# Altium schematic sheet SDEC945-xB_Interface_BP_et_Prog.SchDoc(SDEC945-xB_Interface_BP_et_Prog)
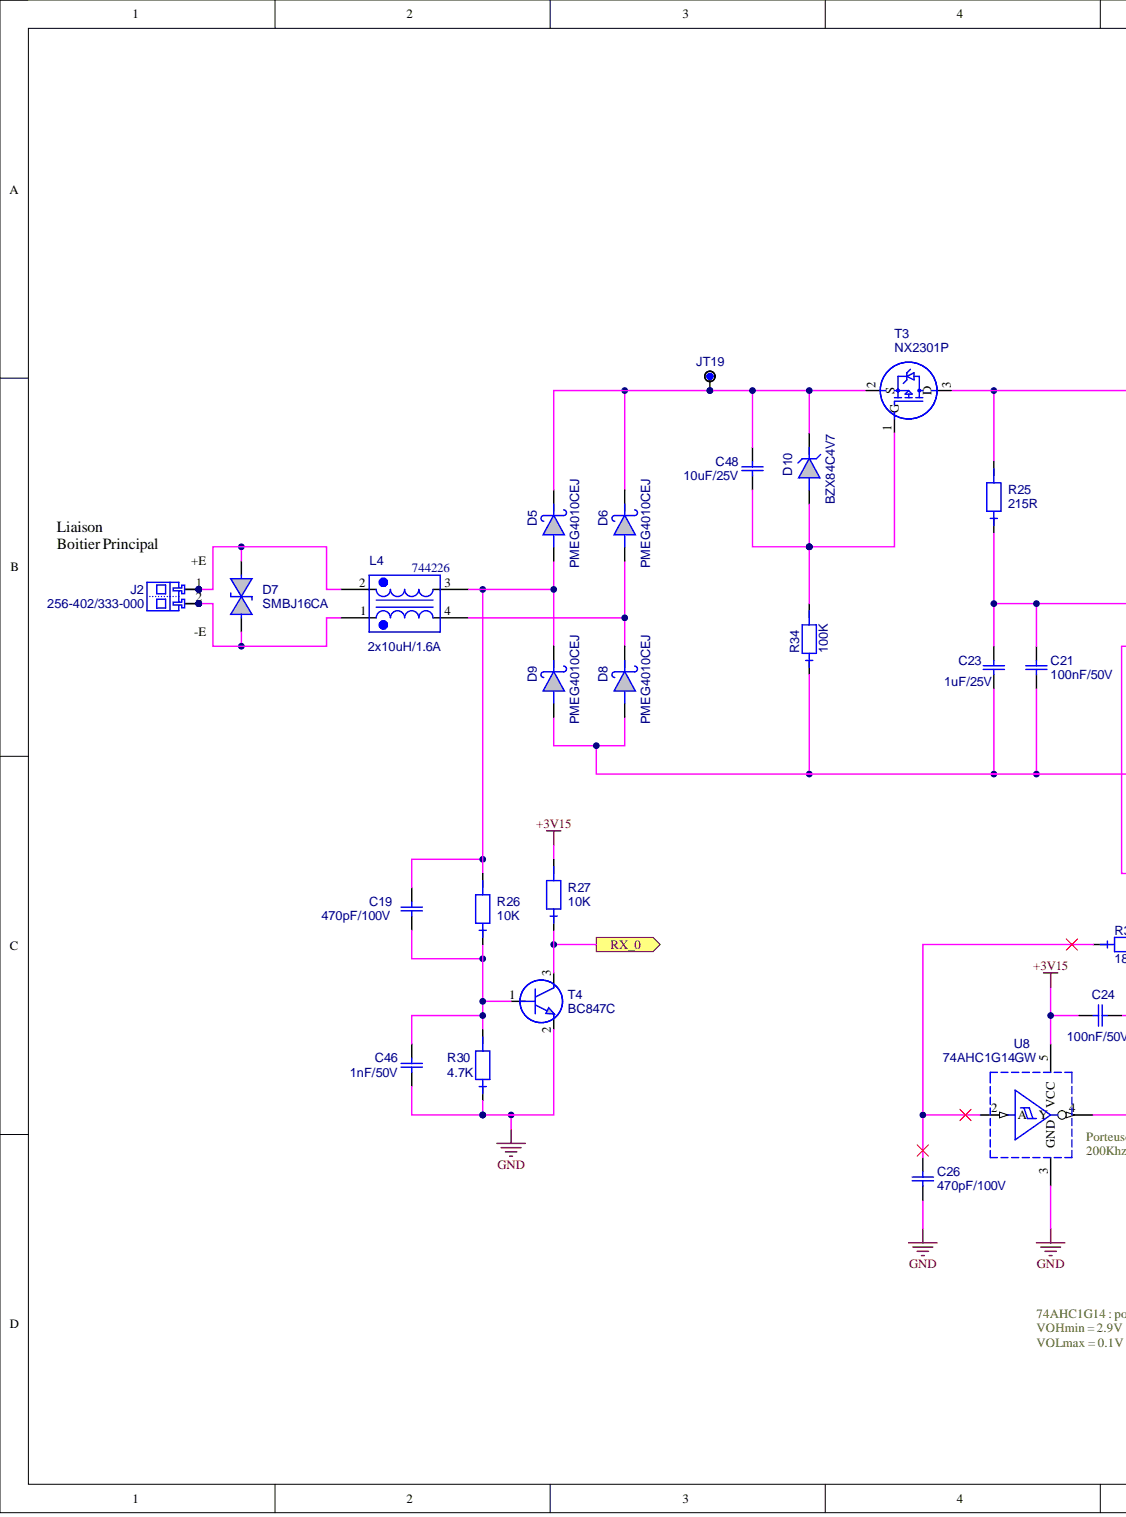
[diagram p0: SDEC945-xB_Interface_BP_et_Prog.SchDoc, left]
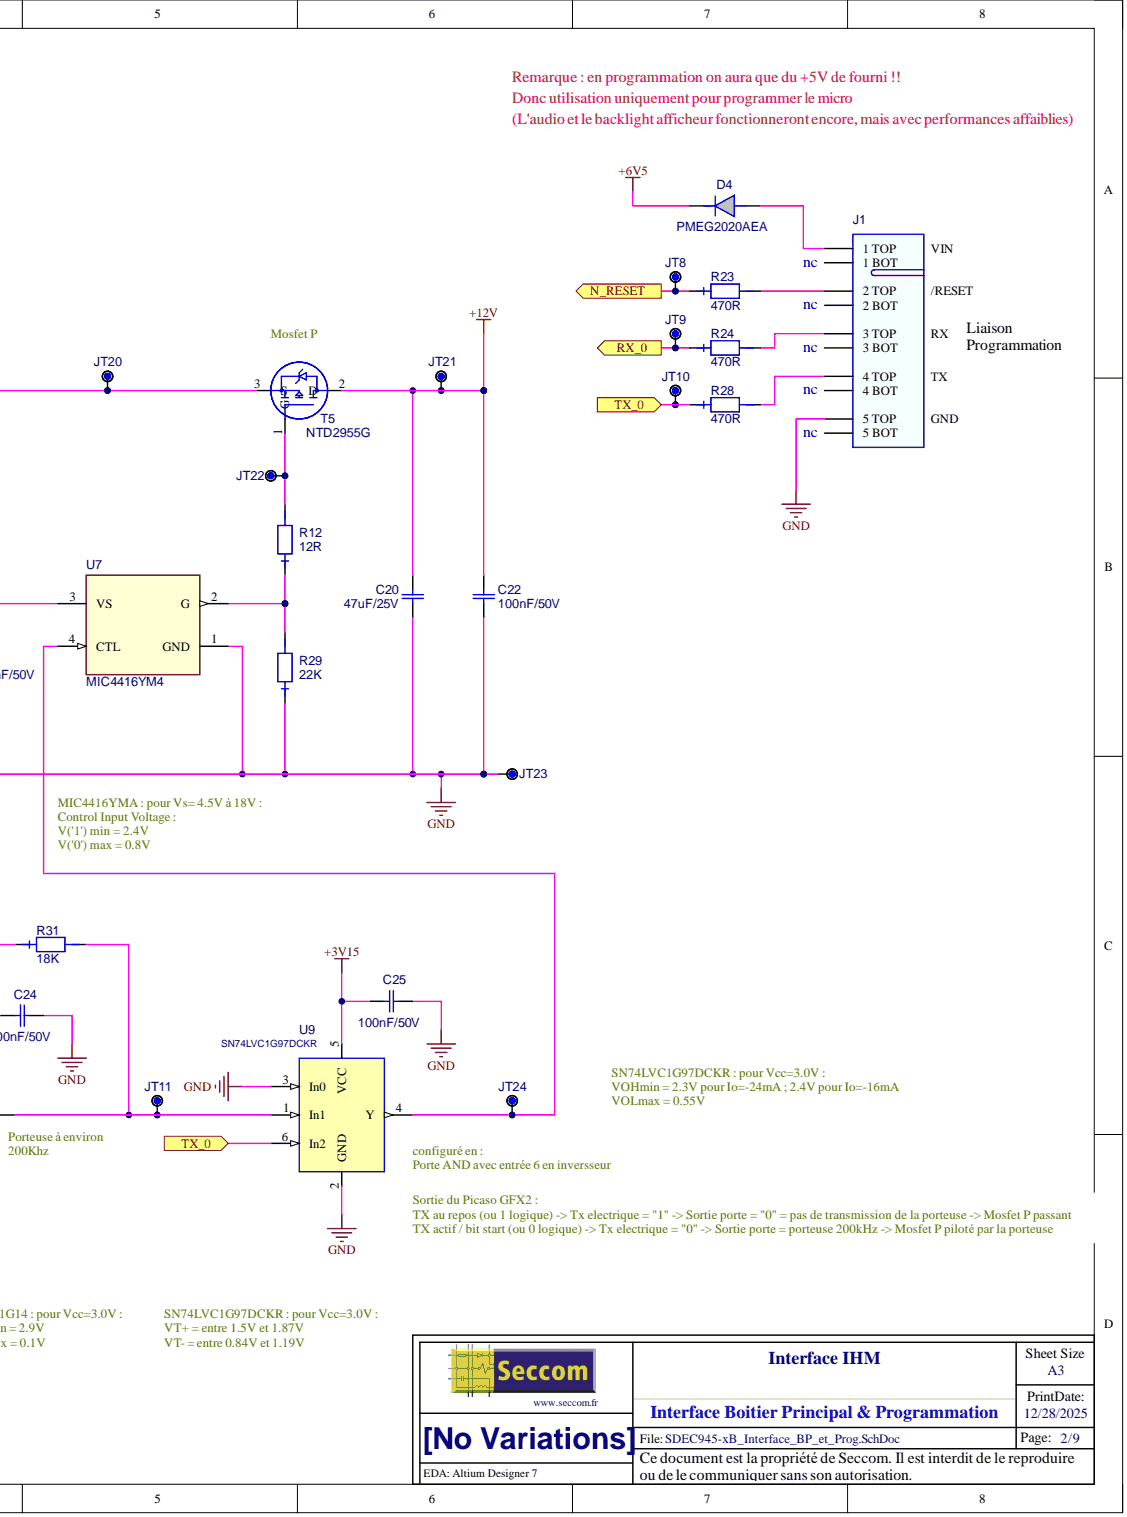
[diagram p1: SDEC945-xB_Interface_BP_et_Prog.SchDoc, right]

## Parts
C19  value 470pF/100V  (hidden comment: C_0805_NPO_5%_470P-100V)
C20  value 47uF/25V  (hidden comment: C_1206_X5R_20%_47U-25V)
C21  value 100nF/50V  (hidden comment: C_0603_X7R_10%_100N-50V)
C22  value 100nF/50V  (hidden comment: C_0603_X7R_10%_100N-50V)
C23  value 1uF/25V  (hidden comment: C_0805_X5R_10%_1U-25V)
C24  value 100nF/50V  (hidden comment: C_0603_X7R_10%_100N-50V)
C25  value 100nF/50V  (hidden comment: C_0603_X7R_10%_100N-50V)
C26  value 470pF/100V  (hidden comment: C_0805_NPO_5%_470P-100V)
C46  value 1nF/50V  (hidden comment: C_0603_NPO_5%_1N-50V)
C48  value 10uF/25V  (hidden comment: C_0805_X5R_10%_10U-25V)
D4  PMEG2020AEA
D5  PMEG4010CEJ
D6  PMEG4010CEJ
D7  SMBJ16CA
D8  PMEG4010CEJ
D9  PMEG4010CEJ
D10  BZX84C4V7
J1  EMB_ZEC_1893711_HOTE  (value not drawn)  (no BOM)
J2  value 256-402/333-000  Ref Fab: 256-402/333-000  (hidden comment: BLEV_CI_45D_5.08_02_256-402/333-000)
JT8  POINT_TEST_PAD_40MIL  (value not drawn)  (no BOM)
JT9  POINT_TEST_PAD_40MIL  (value not drawn)  (no BOM)
JT10  POINT_TEST_PAD_40MIL  (value not drawn)  (no BOM)
JT11  POINT_TEST_PAD_40MIL  (value not drawn)  (no BOM)
JT19  POINT_TEST_PAD_40MIL  (value not drawn)  (no BOM)
JT20  POINT_TEST_PAD_40MIL  (value not drawn)  (no BOM)
JT21  POINT_TEST_PAD_40MIL  (value not drawn)  (no BOM)
JT22  POINT_TEST_PAD_40MIL  (value not drawn)  (no BOM)
JT23  POINT_TEST_PAD_40MIL  (value not drawn)  (no BOM)
JT24  POINT_TEST_PAD_40MIL  (value not drawn)  (no BOM)
L4  value 2x10uH/1.6A  Ref Fab: 744226  (hidden comment: IND_FERRITE_WE-SL2_2X10UH)
R12  value 12R  (hidden comment: RES_0603_1%_100PPM_12R)
R23  value 470R  (hidden comment: RES_0603_1%_100PPM_470R)
R24  value 470R  (hidden comment: RES_0603_1%_100PPM_470R)
R25  value 215R  (hidden comment: RES_0603_1%_100PPM_215R)
R26  value 10K  (hidden comment: RES_0603_1%_100PPM_10K)
R27  value 10K  (hidden comment: RES_0603_1%_100PPM_10K)
R28  value 470R  (hidden comment: RES_0603_1%_100PPM_470R)
R29  value 22K  (hidden comment: RES_0603_1%_100PPM_22K)
R30  value 4.7K  (hidden comment: RES_0603_1%_100PPM_4.7K)
R31  value 18K  (hidden comment: RES_0603_1%_100PPM_18K)
R34  value 100K  (hidden comment: RES_0603_1%_100PPM_100K)
T3  value NX2301P  Ref Fab: NX2301P  (hidden comment: NX2301P_VALENTINEA)
T4  BC847C
T5  NTD2955G
U7  MIC4416YM4
U8  74AHC1G14GW
U9  74LVC1G97DCKR  value SN74LVC1G97DCKR  Ref Fab: SN74LVC1G97DCKR

## Ports
N_RESET, RX_0, TX_0

## Nets
+3V15: C24.2 C25.2 R27.2 U8.5(VCC) U9.5(VCC)
+6V5: D4.K
+12V: C20.2 C22.2 JT21.1 T5.2
GND: C20.1 C21.1 C22.1 C23.1 C24.1 C25.1 C26.1 C46.1 D8.A D9.A J1.5(5_TOP) JT23.1 R29.1 R30.1 R34.1 T4.2 U7.1(GND) U8.3(GND) U9.2(GND) U9.3(In0)
N_RESET: JT8.1 R23.1 ; port N_RESET
RX_0: JT9.1 R24.1 R27.1 T4.3 ; port RX_0
TX_0: JT10.1 R28.1 U9.6(In2) ; port TX_0
NetC19_1: C19.1 C46.2 R26.1 R30.2 T4.1
NetC19_2: C19.2 D5.A D9.K L4.3 R26.2
NetC21_2: C21.2 C23.2 R25.1 U7.3(VS)
NetC26_2: C26.2 R31.1 U8.2(A)
NetC48_1: C48.1 D10.1 R34.2 T3.1
NetC48_2: C48.2 D5.K D6.K D10.3 JT19.1 T3.2
NetD4_A: D4.A J1.1(1_TOP)
NetD6_A: D6.A D8.K L4.4
NetD7_1: D7.1 J2.2 J2.4 L4.1
NetD7_2: D7.2 J2.1 J2.3 L4.2
NetJ1_2: J1.2(2_TOP) R23.2
NetJ1_3: J1.3(3_TOP) R24.2
NetJ1_4: J1.4(4_TOP) R28.2
NetJT11_1: JT11.1 R31.2 U8.4(Y) U9.1(In1)
NetJT20_1: JT20.1 R25.2 T3.3 T5.3
NetJT22_1: JT22.1 R12.2 T5.1
NetJT24_1: JT24.1 U7.4(CTL) U9.4(Y)
NetR12_1: R12.1 R29.2 U7.2(G)

Not connected: J1 6(1_BOT) 7(2_BOT) 8(3_BOT) 9(4_BOT) 10(5_BOT)

## Library data of the parts
C19 C26 = C_0805_NPO_5%_470P-100V: Condensateur céramique, NPO, 5%, CMS 0805; Boitier: 0805; Coeff: 1; Creation: EC (30/04/10); Fabricant: AVX; Ref Fab: 08051A471JAT2A; Reference: 001384/00; Remarque: {Remarque}; Verification: LJ (20/05/10); Component Kind: Standard; ComponentLink1Description: Datasheet; ComponentLink2Description: Datasheet Boitier; Supplier 1: Farnell; Supplier 2: Mouser; Supplier Part Number 1: 499195; Supplier Part Number 2: 581-08051A471J; ComponentLink1URL: o:\_Documentation\Datasheets\BC_Components\Condo_CMS_NPO-X7R-Y5V.pdf; ComponentLink2URL: o:\_Documentation\Datasheets\_BOITIERS\PASSIF_CMS\RC_0805_(2x1,25)_(Schneider).PDF; Library Name: CAPACITIFS_CMS.SchLib
C20 = C_1206_X5R_20%_47U-25V: Condensateur céramique, X5R, 20%, CMS 1206, 47uF/25V; Boitier: 1206; Coeff: 1; Creation: PDP (13/07/12); Fabricant: TDK; Ref Fab: C3216X5R1E476M; Remarque: {Remarque}; Component Kind: Standard; ComponentLink1Description: Datasheet; ComponentLink2Description: Datasheet Boitier; Library Name: SC94x.SchLib; Supplier 1: Mouser; Supplier Part Number 1: 810-C3216X5R1E476M; ComponentLink1URL: O:\_Documentation\Datasheets\TDK\general_B11.pdf; ComponentLink2URL: o:\_Documentation\Datasheets\_BOITIERS\PASSIF_CMS\
C21 C22 C24 C25 = C_0603_X7R_10%_100N-50V: Condensateur céramique, X7R, 10%, CMS 0603, 100nF/50V; Boitier: 0603; Coeff: 1; Creation: FB (29/02/12); Fabricant: Kemet; Ref Fab: C0603C104K5RAC; Remarque: {Remarque}; Component Kind: Standard; ComponentLink1Description: Datasheet; ComponentLink2Description: Datasheet Boitier; Library Name: SC94x.SchLib; Supplier 1: Farnell; Supplier Part Number 1: 1288255; ComponentLink1URL: O:\_Documentation\Datasheets\Kemet\Condos_Ceramic_CMS.pdf; ComponentLink2URL: o:\_Documentation\Datasheets\_BOITIERS\PASSIF_CMS\
C23 = C_0805_X5R_10%_1U-25V: Condensateur céramique, X5R, 10%, CMS 0805; Boitier: 0805; Coeff: 1; Creation: FB (07/03/12); Fabricant: AVX; Ref Fab: 08053D105KAT2A; Reference: 001834/00; Remarque: {Remarque}; Component Kind: Standard; ComponentLink1Description: Datasheet; ComponentLink2Description: Datasheet Boitier; Supplier 1: Farnell; Supplier 2: Radiospares; Supplier Part Number 1: 1327695; Supplier Part Number 2: 6983409; ComponentLink1URL: O:\_Documentation\Datasheets\AVX\Condensateurs_CMS_Ceramic_X5R.pdf; ComponentLink2URL: o:\_Documentation\Datasheets\_Boitiers\PASSIF_CMS\RC_0805_(2x1,25)_(Schneider).pdf; Library Name: CAPACITIFS_CMS.SchLib
C46 = C_0603_NPO_5%_1N-50V: Condensateur céramique, NPO, 5%, CMS 0603; Boitier: 0603; Coeff: 1; Creation: LJ; Fabricant: AVX; Ref Fab: 06035A102JAT2A; Reference: 001809/00; Remarque: {Remarque}; Component Kind: Standard; ComponentLink1Description: Datasheet; ComponentLink2Description: Datasheet Boitier; Supplier 1: Mouser; Supplier Part Number 1: 581-06035A102J; ComponentLink1URL: o:\_Documentation\Datasheets\BC_Components\Condo_CMS_NPO-X7R-Y5V.pdf; ComponentLink2URL: o:\_Documentation\Datasheets\_BOITIERS\PASSIF_CMS\; Library Name: CAPACITIFS_CMS.SchLib
C48 = C_0805_X5R_10%_10U-25V: Condensateur céramique, X5R, 10%, CMS 0805, 10uF/25V; Boitier: 0805; Coeff: 1; Creation: FB (30/07/13); Fabricant: Murata; Ref Fab: GRM21BR61E106KA73L; Remarque: {Remarque}; Component Kind: Standard; ComponentLink1Description: Datasheet; ComponentLink2Description: Datasheet Boitier; Library Name: SC94x.SchLib; Supplier 1: Farnell; Supplier 2: Mouser; Supplier Part Number 1: 1735530; Supplier Part Number 2: 81-GRM21BR61E106KA3L; ComponentLink1URL: O:\_Documentation\Datasheets\Murata\Condensateur_GRM21BR61E106KA73.pdf; ComponentLink2URL: o:\_Documentation\Datasheets\_Boitiers\PASSIF_CMS\RC_0805_(2x1,25)_(Schneider).pdf
D4 = PMEG2020AEA: Diode Schottky, Vrrm=20V, If=2A, Vf=0.45V, CMS; Boitier: SOD323; Coeff: 1; Creation: PDP (10/07/12); Fabricant: NXP; Remarque: {Remarque}; Component Kind: Standard; ComponentLink1Description: Datasheet; ComponentLink2Description: Datasheet Boitier; Library Name: SC94x.SchLib; Ref Fab: PMEG2020AEA; Value: PMEG2020AEA; ComponentLink1URL: o:\_Documentation\Datasheets\NXP\PMEG2020AEA.PDF; ComponentLink2URL: o:\_Documentation\Datasheets\_BOITIERS\ACTIF_CMS\SOD\SOD_80_(MLL34)_(Schneider).PDF
D5 D6 D8 D9 = PMEG4010CEJ: Diode Schottky, Vrrm=40V, If=1A, Vf=0.49V, CMS, -65/+150°C; Boitier: SOD323F; Coeff: 1; Creation: FB (30/07/13); Fabricant: NXP; Remarque: {Remarque}; Component Kind: Standard; ComponentLink1Description: Datasheet; ComponentLink2Description: Datasheet Boitier; Library Name: SC94x.SchLib; Ref Fab: PMEG4010CEJ; Supplier 1: Farnell; Supplier 2: Mouser; Supplier Part Number 1: 1757777; Supplier Part Number 2: 771-PMEG4010CEJ-T/R; Value: PMEG4010CEJ; ComponentLink1URL: O:\_Documentation\Datasheets\NXP\PMEG4010CEH_PMEG4010CEJ.pdf
D7 = SMBJ16CA: Diode Transil/TVS bidirectionnelle (CA), 600W, 16V, CMS, serie SMBJ; Boitier: DO214AA/SMB; Coeff: 1; Creation: FB (30/07/13); Fabricant: Bourns; Remarque: {Remarque}; Component Kind: Standard; ComponentLink1Description: Datasheet; ComponentLink2Description: Datasheet Boitier; Library Name: SC94x.SchLib; Ref Fab: SMBJ16CA; Supplier 1: Mouser; Supplier Part Number 1: 652-SMBJ16CA; Value: SMBJ16CA; ComponentLink1URL: O:\_Documentation\Datasheets\Bourns\SMBJ_diodes_TVS_600W.pdf; ComponentLink2URL: o:\_Documentation\Datasheets\_BOITIERS\ACTIF_CMS\SMx\SMB_(Schneider).lnk
D10 = BZX84C4V7: Diode Zener, série BZX84C, 0.3W, +/-5%, 4.7V, CMS; Boitier: SOT23_3L; Coeff: 1; Creation: LJ; Fabricant: NXP; Reference: 000212/00; Remarque: {Remarque}; Verification: LJ (25/02/02); Component Kind: Standard; ComponentLink1Description: Datasheet; ComponentLink2Description: Datasheet Boitier; Library Name: DIODES.SchLib; Ref Fab: BZX84C4V7; Supplier 1: Farnell; Supplier 2: Mouser; Supplier Part Number 1: 1081428; Supplier Part Number 2: 771-BZX84-C4V7-T/R; Value: BZX84C4V7; ComponentLink1URL: o:\_Documentation\Datasheets\NXP\BZX84_SERIES.pdf; ComponentLink2URL: o:\_Documentation\Datasheets\_BOITIERS\ACTIF_CMS\SOT\SOT_23_3P_(Schneider).PDF
J1 = EMB_ZEC_1893711_HOTE: Implantation CI pour connecteur ZEC 1,0/5-ST-3,5 C1 R1,5 (ref 1893711); Coeff: 1; Creation: JPL (18/07/12); Fabricant: Phoenix Contact; ComponentLink1Description: Datasheet; ComponentLink2Description: Datasheet Boitier; Library Name: SC94x.SchLib
J2 = BLEV_CI_45D_5.08_02_256-402/333-000: Bornier à levier pour CI, e5.08, 2pts, 45 degrés, 300V/15A, AWG 28-12, Gris; Coeff: 1; Creation: LJ; Fabricant: Wago; Remarque: {Remarque}; Component Kind: Standard; ComponentLink1Description: Datasheet; ComponentLink2Description: Datasheet; Library Name: SC94x.SchLib; Supplier 1: Farnell; Supplier Part Number 1: 1695013
JT8-JT11 JT19-JT24 = POINT_TEST_PAD_40MIL: Point de test sur CI, pad de diamètre 1.016mm (40mils); Coeff: 1; Creation: FB (04/10/12); Remarque: {Remarque}; ComponentLink1Description: Datasheet; ComponentLink2Description: Datasheet Boitier; Value: POINT_TEST; Library Name: CONN_PICOT.SchLib
L4 = IND_FERRITE_WE-SL2_2X10UH: Filtre double ferrite, 2x10uH, 42Vac/80Vdc, 1.6A, serie WE-SL2, -40/+125°C, CMS; Boitier: WE-SL2_9.2x6_h5mm; Coeff: 1; Creation: FB (19/03/12); Fabricant: Wurth Elektronik; Component Kind: Standard; ComponentLink1Description: Datasheet; ComponentLink2Description: Datasheet Boitier; Library Name: SC94x.SchLib; Supplier 1: Farnell; Supplier 2: Radiospares; Supplier Part Number 1: 1636269; Supplier Part Number 2: 3675045; ComponentLink1URL: O:\_Documentation\Datasheets\Wurth_Elektronik\744226.pdf; ComponentLink2URL: o:\_Documentation\Datasheets\_BOITIERS\
R12 = RES_0603_1%_100PPM_12R: Résistance, 0.100W, 1%, 100ppm, 75V, 12R; Boitier: 0603; Coeff: 1; Creation: FB (11/09/12); Fabricant: Vishay; Ref Fab: CRCW060312R0FKEA; Remarque: {Remarque}; Component Kind: Standard; ComponentLink1Description: Datasheet; ComponentLink2Description: Datasheet Boitier; Library Name: SC94x.SchLib; Supplier 1: Farnell; Supplier 2: Mouser; Supplier Part Number 1: 1652838; Supplier Part Number 2: 71-CRCW0603-12-E3; ComponentLink1URL: O:\_Documentation\Datasheets\Vishay\Resistances_D-CRCWe3.pdf; ComponentLink2URL: o:\_Documentation\Datasheets\_BOITIERS\PASSIF_CMS\
R23 R24 R28 = RES_0603_1%_100PPM_470R: Résistance, 0.100W, 1%, 100ppm, 75V, 470R; Boitier: 0603; Coeff: 1; Creation: FB (29/07/13); Fabricant: Vishay; Ref Fab: CRCW0603470RFKEA; Remarque: {Remarque}; Component Kind: Standard; ComponentLink1Description: Datasheet; ComponentLink2Description: Datasheet Boitier; Library Name: SC94x.SchLib; Supplier 1: Farnell; Supplier 2: Mouser; Supplier Part Number 1: 1469815; Supplier Part Number 2: 71-CRCW0603-470-E3; ComponentLink1URL: O:\_Documentation\Datasheets\Vishay\Resistances_D-CRCWe3.pdf; ComponentLink2URL: o:\_Documentation\Datasheets\_BOITIERS\PASSIF_CMS\
R25 = RES_0603_1%_100PPM_215R: Résistance, 0.100W, 1%, 100ppm, 75V, 215R; Boitier: 0603; Coeff: 1; Creation: FB (05/03/12); Fabricant: Vishay; Ref Fab: CRCW0603215RFKEA; Remarque: {Remarque}; Component Kind: Standard; ComponentLink1Description: Datasheet; ComponentLink2Description: Datasheet Boitier; Library Name: SC94x.SchLib; Supplier 1: Farnell; Supplier 2: RadioSpares; Supplier Part Number 1: 1252503; Supplier Part Number 2: 6789976; ComponentLink1URL: O:\_Documentation\Datasheets\Vishay\Resistances_D-CRCWe3.pdf; ComponentLink2URL: o:\_Documentation\Datasheets\_BOITIERS\PASSIF_CMS\
R26 R27 = RES_0603_1%_100PPM_10K: Résistance, 0.100W, 1%, 100ppm, 75V, 10K; Boitier: 0603; Coeff: 1; Creation: JPL (05/07/12); Fabricant: Vishay; Ref Fab: CRCW060310K0FKEA; Remarque: {Remarque}; Component Kind: Standard; ComponentLink1Description: Datasheet; ComponentLink2Description: Datasheet Boitier; Library Name: SC94x.SchLib; Supplier 1: Farnell; Supplier 2: RadioSpares; Supplier Part Number 1: 1469748; Supplier Part Number 2: 6789667; ComponentLink1URL: O:\_Documentation\Datasheets\Vishay\Resistances_D-CRCWe3.pdf; ComponentLink2URL: o:\_Documentation\Datasheets\_BOITIERS\PASSIF_CMS\
R29 = RES_0603_1%_100PPM_22K: Résistance, 0.100W, 1%, 100ppm, 75V, 22K; Boitier: 0603; Coeff: 1; Creation: JPL (05/07/12); Fabricant: Vishay; Ref Fab: CRCW060322K0FKEA; Remarque: {Remarque}; Component Kind: Standard; ComponentLink1Description: Datasheet; ComponentLink2Description: Datasheet Boitier; Library Name: SC94x.SchLib; Supplier 1: Farnell; Supplier 2: RadioSpares; Supplier Part Number 1: 1469779; Supplier Part Number 2: 6790005; ComponentLink1URL: O:\_Documentation\Datasheets\Vishay\Resistances_D-CRCWe3.pdf; ComponentLink2URL: o:\_Documentation\Datasheets\_BOITIERS\PASSIF_CMS\
R30 = RES_0603_1%_100PPM_4.7K: Résistance, 0.100W, 1%, 100ppm, 75V, 4.7K; Boitier: 0603; Coeff: 1; Creation: JPL (05/07/12); Fabricant: Vishay; Ref Fab: CRCW06034K70FKEA; Remarque: {Remarque}; Component Kind: Standard; ComponentLink1Description: Datasheet; ComponentLink2Description: Datasheet Boitier; Library Name: SC94x.SchLib; Supplier 1: Farnell; Supplier 2: RadioSpares; Supplier Part Number 1: 1469807; Supplier Part Number 2: 6790484; ComponentLink1URL: O:\_Documentation\Datasheets\Vishay\Resistances_D-CRCWe3.pdf; ComponentLink2URL: o:\_Documentation\Datasheets\_BOITIERS\PASSIF_CMS\
R31 = RES_0603_1%_100PPM_18K: Résistance, 0.100W, 1%, 100ppm, 75V, 18K; Boitier: 0603; Coeff: 1; Creation: JPL (05/07/12); Fabricant: Vishay; Ref Fab: CRCW060318K0FKEA; Remarque: {Remarque}; Component Kind: Standard; ComponentLink1Description: Datasheet; ComponentLink2Description: Datasheet Boitier; Library Name: SC94x.SchLib; Supplier 1: Farnell; Supplier 2: RadioSpares; Supplier Part Number 1: 1692519; Supplier Part Number 2: 6789857; ComponentLink1URL: O:\_Documentation\Datasheets\Vishay\Resistances_D-CRCWe3.pdf; ComponentLink2URL: o:\_Documentation\Datasheets\_BOITIERS\PASSIF_CMS\
R34 = RES_0603_1%_100PPM_100K: Résistance, 0.100W, 1%, 100ppm, 75V, 100K; Boitier: 0603; Coeff: 1; Creation: FB (02/10/12); Fabricant: Vishay; Ref Fab: CRCW0603100KFKEA; Remarque: {Remarque}; Component Kind: Standard; ComponentLink1Description: Datasheet; ComponentLink2Description: Datasheet Boitier; Library Name: SC94x.SchLib; Supplier 1: Farnell; Supplier 2: Mouser; Supplier Part Number 1: 1469649; Supplier Part Number 2: 71-CRCW0603-100K-E3; ComponentLink1URL: O:\_Documentation\Datasheets\Vishay\Resistances_D-CRCWe3.pdf; ComponentLink2URL: o:\_Documentation\Datasheets\_BOITIERS\PASSIF_CMS\
T3 = NX2301P_VALENTINEA: Transistor Mosfet P, Vgs(max)=+/-8V, Vds(max)=-20V, Id=-2A, Rdson=0.100R, 0.4W, -55/+150°C, CMS; Boitier: SOT23; Coeff: 1; Creation: FB (31/07/13); Fabricant: NXP; Remarque: AEC-Q101; Component Kind: Standard; ComponentLink1Description: Datasheet; ComponentLink2Description: Datasheet Boitier; Library Name: SC94x.SchLib; Supplier 1: Farnell; Supplier 2: Mouser; Supplier Part Number 1: 1894738; Supplier Part Number 2: 771-NX2301P215; ComponentLink1URL: o:\_Documentation\Datasheets\NXP\NX2301P.pdf; ComponentLink2URL: o:\_Documentation\Datasheets\NXP\NX2301P.pdf
T4 = BC847C: Transistor NPN, Vce=50V, Ic=0.1A, hfe=420~800, 0.25W, CMS; Boitier: SOT23_3L; Coeff: 1; Creation: GO (08/07/05); Fabricant: NXP; Reference: 000329/00; Remarque: Complementaires aux BC856-860; Verification: LJ (21/07/05); Component Kind: Standard; ComponentLink1Description: Datasheet; ComponentLink2Description: Datasheet Boitier; Library Name: TRANSISTOR.SchLib; Ref Fab: BC847C; Supplier 1: Farnell; Supplier Part Number 1: 1081235; Value: BC847C; ComponentLink1URL: O:\_Documentation\Datasheets\NXP\BC847.pdf; ComponentLink2URL: o:\_Documentation\Datasheets\_BOITIERS\ACTIF_CMS\SOT\SOT_23_3P_(Schneider).PDF
T5 = NTD2955G: Transistor Mosfet P, Vdss=60V, Id=12A, Rdson=0.155R, -55/+175°C, CMS; Boitier: DPAK; Coeff: 1; Creation: PDP (13/07/12); Fabricant: ON Semiconductor; Component Kind: Standard; ComponentLink1Description: Datasheet; ComponentLink2Description: Datasheet Boitier; Library Name: SC94x.SchLib; Ref Fab: NTD2955G; Supplier 1: Farnell; Supplier 2: Mouser; Supplier Part Number 1: 2101431; Supplier Part Number 2: 863-NTD2955G; Value: NTD2955G; ComponentLink1URL: O:\_Documentation\Datasheets\ON_Semiconductors\NTD2955.PDF; ComponentLink2URL: o:\_Documentation\Datasheets\_BOITIERS\ACTIF_CMS\
U7 = MIC4416YM4: Low side MOSFET Driver ,+4.5V to +18V,1.2A peak, non-inverting, -40/+85°C, CMS; Boitier: SOT143; Coeff: 1; Creation: CHD (21/02/12); Fabricant: Micrel; Verification: FB (11/09/12); Component Kind: Standard; ComponentLink1Description: Datasheet; ComponentLink2Description: Datasheet Boitier; Library Name: SC94x.SchLib; Ref Fab: MIC4416YM4; Supplier 1: Farnell; Supplier Part Number 1: 1100664; Value: MIC4416YM4; ComponentLink1URL: O:\_Documentation\Datasheets\Micrel\MIC4416_4417.pdf
U8 = 74AHC1G14GW: Inverseur simple, Trigger de Schmitt, AHC, Picogate, CMS; Boitier: SOT353; Coeff: 1; Creation: LJ (25/06/09); Fabricant: NXP; Reference: 000742/00; Remarque: {Remarque}; Component Kind: Standard; ComponentLink1Description: Datasheet; ComponentLink2Description: Datasheet Boitier; Ref Fab: 74AHC1G14GW; Supplier 1: Farnell; Supplier Part Number 1: 1085241; Value: 74AHC1G14GW; ComponentLink1URL: o:\_Documentation\Datasheets\NXP\74AHC1G14-74AHCT1G14.pdf; ComponentLink2URL: o:\_Documentation\Datasheets\_BOITIERS\ACTIF_CMS\SOT\SOT_23_5P_(Schneider).pdf; Library Name: CI_LOGIQUE.SchLib
U9 = 74LVC1G97DCKR: Porte logique multifonction, AND/OR/NAND/NOR/INV/BUFFER, LVC, CMS SC70_6; Coeff: 1; Creation: LJ (01/08/08); Fabricant: Texas Instruments; Reference: 000193/00; Remarque: {Remarque}; Boitier: SC70_6; Component Kind: Standard; ComponentLink1Description: Datasheet; ComponentLink2Description: Datasheet Boitier; Supplier 1: Farnell; Supplier 2: Mouser; Supplier Part Number 1: 1470839; Supplier Part Number 2: 595-SN74LVC1G97DCKR; ComponentLink1URL: o:\_Documentation\Datasheets\Texas\SN74LVC1G97.pdf; ComponentLink2URL: o:\_Documentation\Datasheets\_Boitiers\ACTIF_CMS\SC\SC70_6_e0,65_(NSC).pdf; Library Name: CI_LOGIQUE.SchLib
